# Altium schematic sheet Main.SchDoc
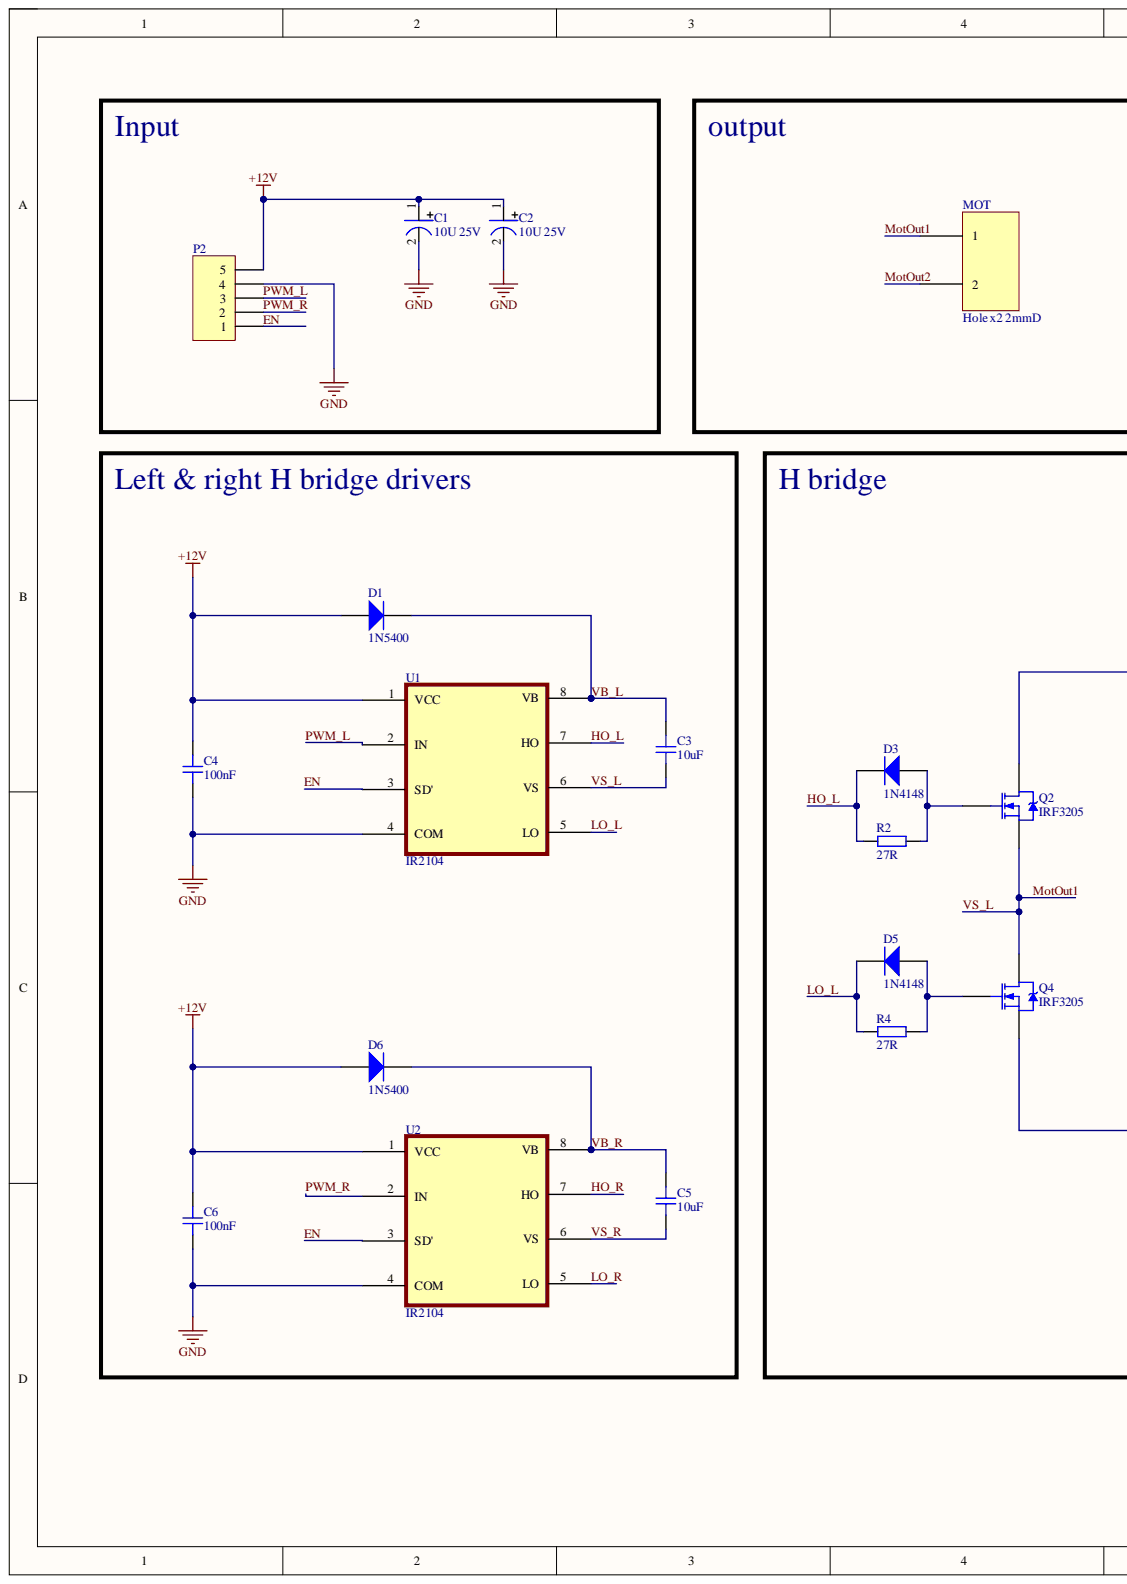
[diagram p0: Main.SchDoc, left]
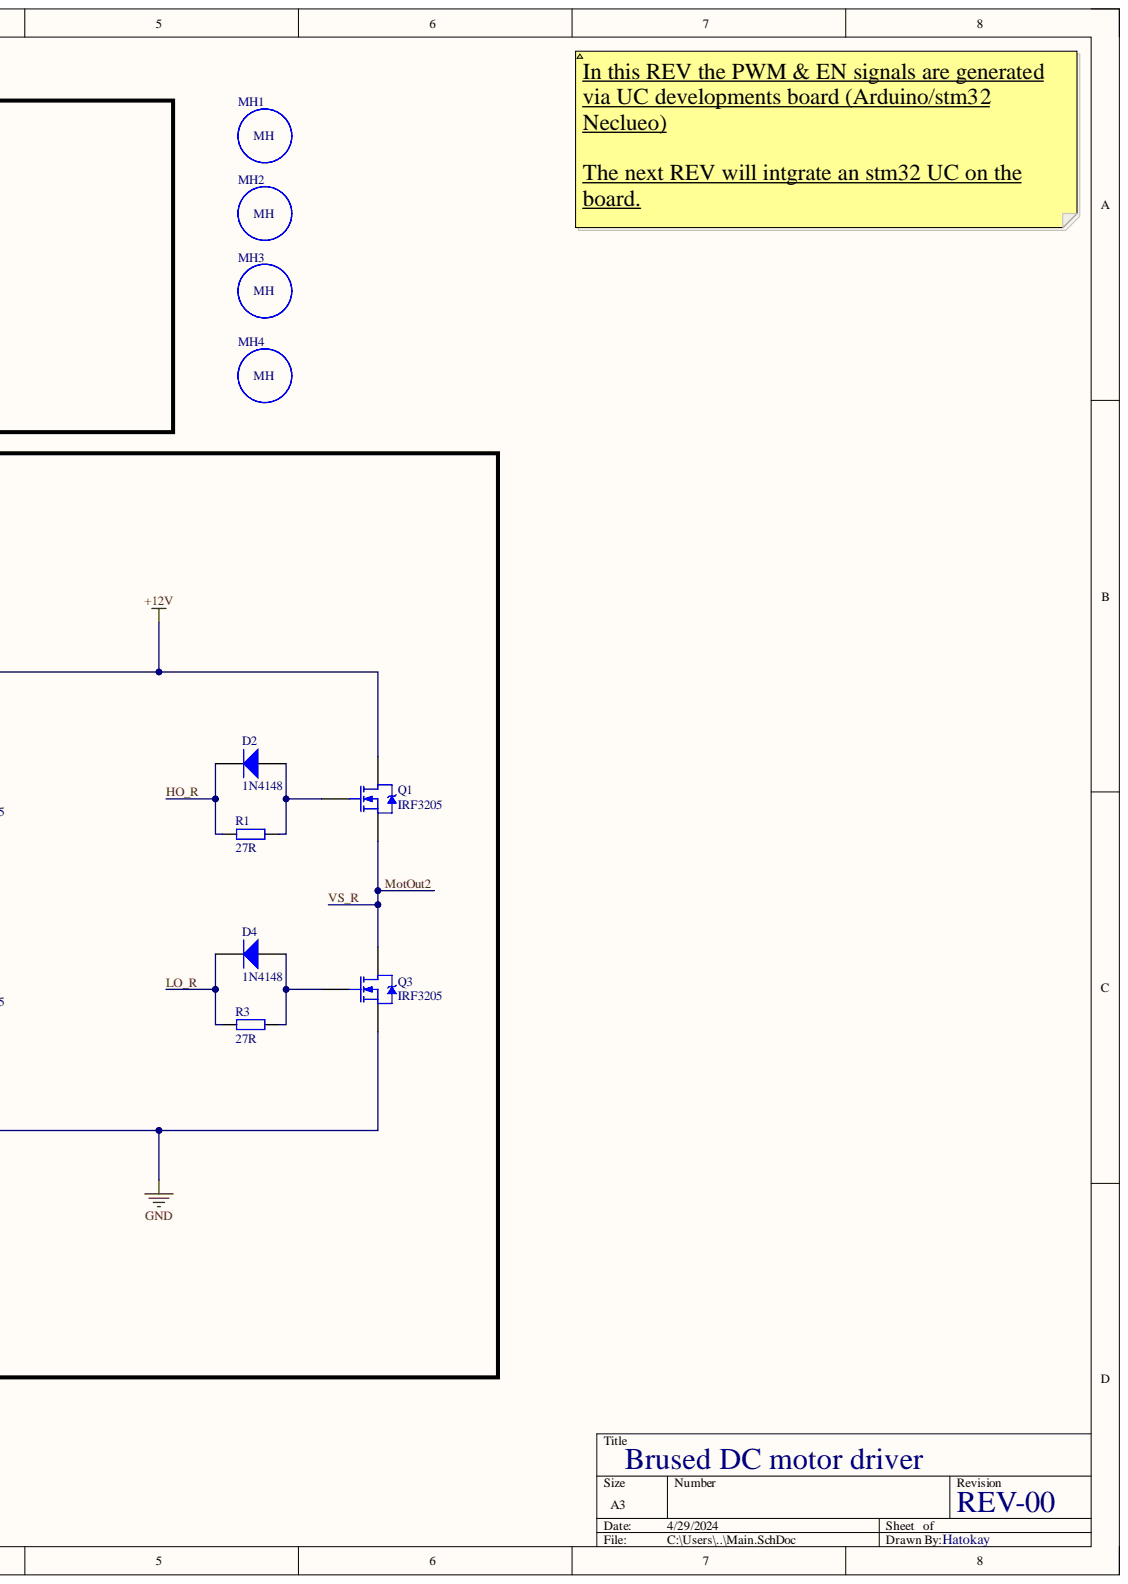
[diagram p1: Main.SchDoc, right]

## Parts
C1  10U 25V  [CAP_POL_10X5.5X0.7]
C2  10U 25V  [CAP_POL_10X5.5X0.7]
C3  10uF  [CAP_0603]
C4  100nF  [CAP_0402]
C5  10uF  [CAP_0603]
C6  100nF  [CAP_0402]
D1  1N5400  [My_DO201-15]
D2  1N4148  [DO-35]
D3  1N4148  [DO-35]
D4  1N4148  [DO-35]
D5  1N4148  [DO-35]
D6  1N5400  [My_DO201-15]
MH1  3mm Mounting Hole  [3mm Mouning Hole]  (value not drawn)
MH2  3mm Mounting Hole  [3mm Mouning Hole]  (value not drawn)
MH3  3mm Mounting Hole  [3mm Mouning Hole]  (value not drawn)
MH4  3mm Mounting Hole  [3mm Mouning Hole]  (value not drawn)
MOT  Hole x2 2mmD  [2mm holes - 8mm apart]
P2  Header 5  [My_1X05]  (value not drawn)
Q1  IRF3205  [TO-220AB]
Q2  IRF3205  [TO-220AB]
Q3  IRF3205  [TO-220AB]
Q4  IRF3205  [TO-220AB]
R1  27R  [RES_0402]
R2  27R  [RES_0402]
R3  27R  [RES_0402]
R4  27R  [RES_0402]
U1  IR2104  [IR2104]
U2  IR2104  [IR2104]

## Nets
+12V: C1.1 C2.1 C4.2 C6.2 D1.A D6.A P2.5 Q1.2 Q2.2 U1.1(VCC) U2.1(VCC)
GND: C1.2 C2.2 C4.1 C6.1 P2.4 Q3.3 Q4.3 U1.4(COM) U2.4(COM)
EN: P2.1 U1.3(SD') U2.3(SD')
HO_L: D3.2 R2.1 U1.7(HO)
HO_R: D2.2 R1.1 U2.7(HO)
LO_L: D5.2 R4.1 U1.5(LO)
LO_R: D4.2 R3.1 U2.5(LO)
MotOut1: C3.1 MOT.1 Q2.3 Q4.2 U1.6(VS)
MotOut2: C5.1 MOT.2 Q1.3 Q3.2 U2.6(VS)
PWM_L: P2.3 U1.2(IN)
PWM_R: P2.2 U2.2(IN)
VB_L: C3.2 D1.K U1.8(VB)
VB_R: C5.2 D6.K U2.8(VB)
NetD2_1: D2.1 Q1.1 R1.2
NetD3_1: D3.1 Q2.1 R2.2
NetD4_1: D4.1 Q3.1 R3.2
NetD5_1: D5.1 Q4.1 R4.2

## Library data of the parts
C1 C2 = CAP_POL_10X5.5X0.7: Component Kind: Standard; Library Name: Noah_LIB.IntLib
  C2: Description: CAP_POL_10X5.5X0.7
C3 C5 = CAP_0603: Capacitor SMD 0603; Component Kind: Standard; Library Name: Schematic-Lib-PhilsLab.SchLib
C4 C6 = CAP_0402: Capacitor SMD 0402; Component Kind: Standard; Library Name: Schematic-Lib-PhilsLab.SchLib
D1 D6 = 1N5400: 3 Amp Diode; ASiD Part Number: 1N5408-AS; Component Kind: Standard; Library Name: Mylib+Rev+4 (1).IntLib
D2-D5 = Diode 1N4148: High Conductance Fast Diode; Code_JEDEC: DO-204-AH; Component Kind: Standard; ComponentLink1Description: Manufacturer Link; ComponentLink1URL: http://www.fairchildsemi.com/; ComponentLink2Description: Datasheet; ComponentLink2URL: http://www.fairchildsemi.com/ds/1N%2F1N4148.pdf; DatasheetVersion: 1997; LatestRevisionDate: 17-Jul-2002; LatestRevisionNote: Re-released for DXP Platform.; Library Name: Miscellaneous Devices.IntLib; PackageDescription: Diode, Axial; Body 3.8 x 1.9 mm (LxDia. max), Lead Dia. 0.53 mm (max); PackageReference: DO-35; Published: 8-Jun-2000; Signal Integrity: 1N4148; Simulation: 1N4148; Publisher: Altium Limited
MH1-MH4 = 3mm Mounting Hole: 3mm MH; Component Kind: Standard; Library Name: Noah_LIB.IntLib
MOT = Hole x2 2mmD: two mounting/solder holes. 2mm diamitter holes, spaced at 8mm.; Component Kind: Standard; Library Name: Noah_LIB.IntLib
P2 = Header 5: Header, 5-Pin; ASiD Part Number: Header 5-AS; Component Kind: Standard; Library Name: Mylib+Rev+4 (1).IntLib; Signal Integrity: Connector
Q1-Q4 = IRF3205: HEXFET Power MOSFET; Note: Pin 2 is electrically connected to pin 4.; Component Kind: Standard; ComponentLink1Description: Manufacturer Link; ComponentLink1URL: http://www.irf.com/; ComponentLink2Description: Datasheet; ComponentLink2URL: http://www.irf.com/product-info/datasheets/data/irf3205.pdf; DatasheetVersion: Aug-1997; LatestRevisionDate: 17-Jul-2002; LatestRevisionNote: Re-released for DXP Platform.; Library Name: IR Discrete MOSFET - Power.IntLib; PackageDescription: TO, Thru-Hole, Vertical, Heatsink Mounted; 3 In-Line Leads; Pitch 2.54 mm; PackageReference: TO-220AB; PackageVersion: Feb-1997; Published: 8-Jun-2000; Simulation: IRF3205; Publisher: Altium Limited
R1-R4 = RES_0402: Resistor SMD 0402; Component Kind: Standard; Library Name: Schematic-Lib-PhilsLab.SchLib
U1 U2 = IR2104: Component Kind: Standard; Description: IR2104; Library Name: Noah_LIB.IntLib
